# Altium schematic sheet HeatCompressPCB.SchDoc
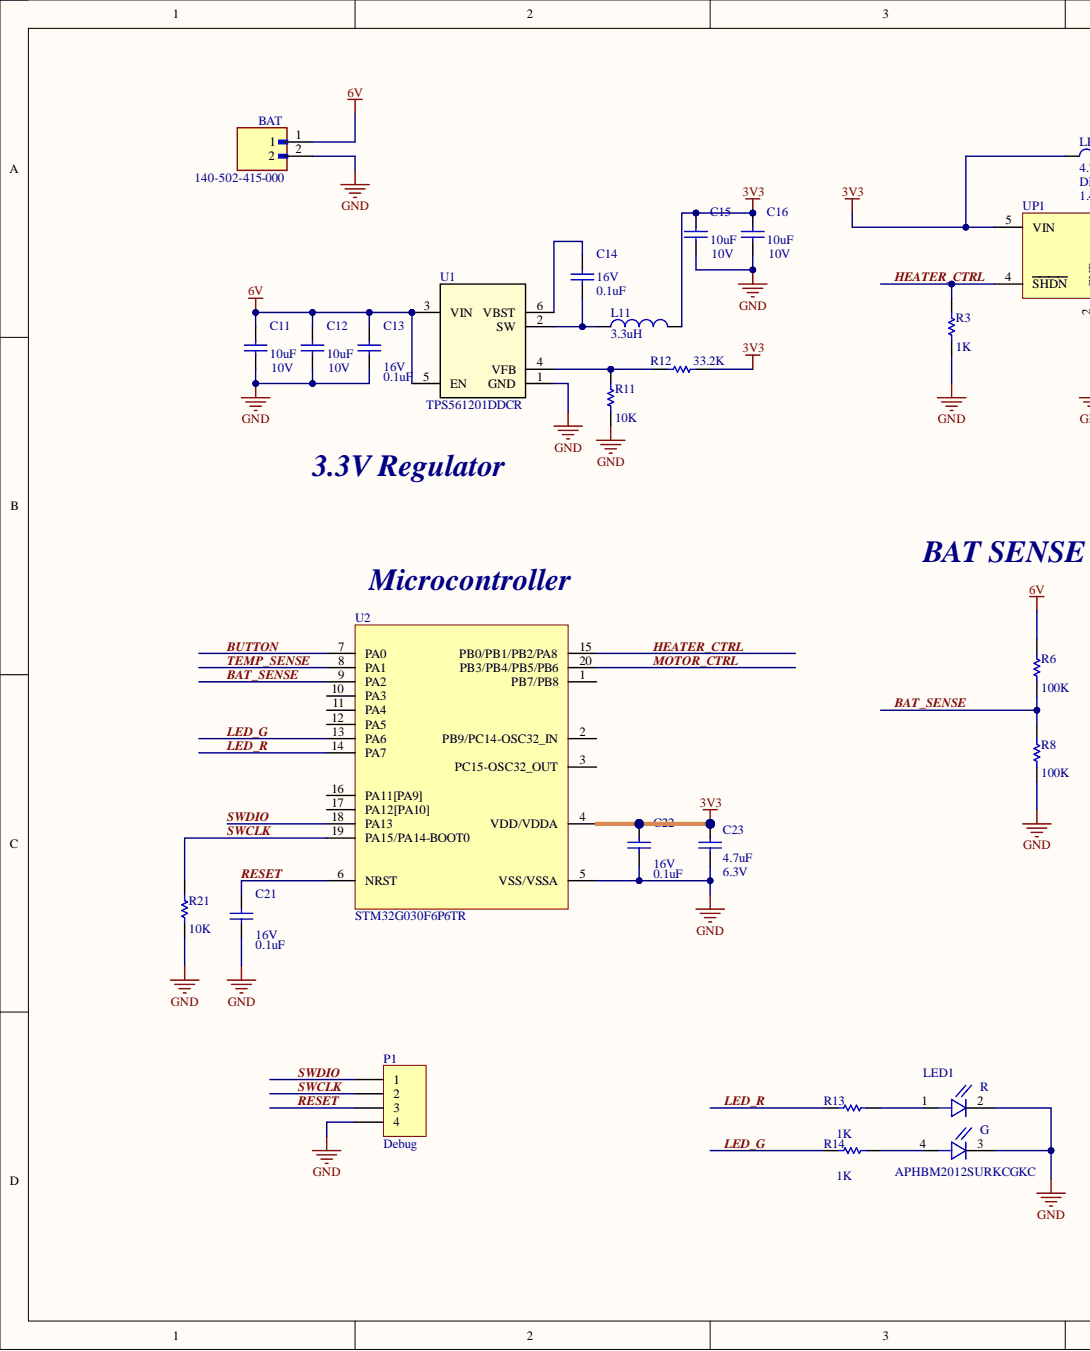
[diagram p0: HeatCompressPCB.SchDoc, left]
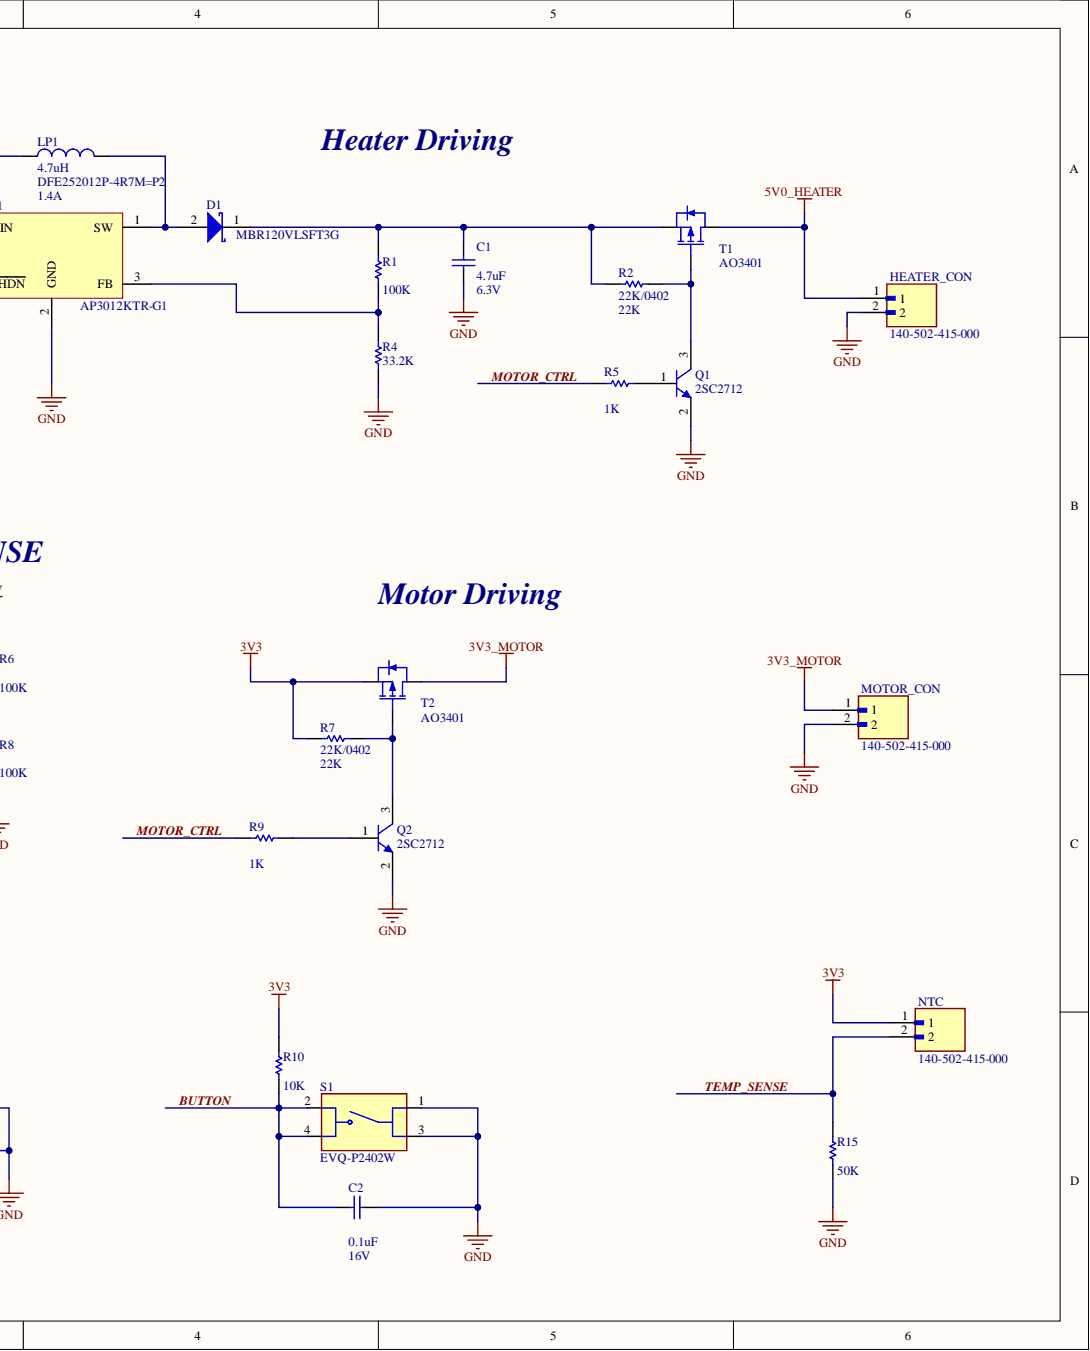
[diagram p1: HeatCompressPCB.SchDoc, right]

## Parts
BAT  140-502-415-000  [140502415000]
C1  value 4.7uF  Capacitance: 4.7uF  Voltage Rating: 6.3V  [C0402]  (hidden comment: 4.7uF/6.3V)
C2  value 0.1uF  Voltage Rating: 16V  [C0402]  (hidden comment: 0.1uF/16V)
C11  value 10uF  Capacitance: 10uF  Voltage Rating: 10V  [C0402]  (hidden comment: 10uF/10V)
C12  value 10uF  Capacitance: 10uF  Voltage Rating: 10V  [C0402]  (hidden comment: 10uF/10V)
C13  0.1uF/16V  value 0.1uF  Voltage Rating: 16V  [C0402]
C14  0.1uF/16V  value 0.1uF  Voltage Rating: 16V  [C0402]
C15  value 10uF  Capacitance: 10uF  Voltage Rating: 10V  [C0402]  (hidden comment: 10uF/10V)
C16  value 10uF  Capacitance: 10uF  Voltage Rating: 10V  [C0402]  (hidden comment: 10uF/10V)
C21  0.1uF/16V  value 0.1uF  Voltage Rating: 16V  [C0402]
C22  0.1uF/16V  value 0.1uF  Voltage Rating: 16V  [C0402]
C23  value 4.7uF  Capacitance: 4.7uF  Voltage Rating: 6.3V  [C0402]  (hidden comment: 4.7uF/6.3V)
D1  MBR120VLSFT3G  [SOD-123FL]
HEATER_CON  140-502-415-000  [140502415000]
L11  3.3uH  [DFE252012P-1R0M=P2]
LED1  APHBM2012SURKCGKC  [LED_APHBM2012SURKCGKC]
LP1  4.7uH  Manufacturer Part Number: DFE252012P-4R7M=P2  [DFE252012P-1R0M=P2]
MOTOR_CON  140-502-415-000  [140502415000]
NTC  140-502-415-000  [140502415000]
P1  Debug  [MHDR1X4]
Q1  2SC2712  [SOT-23]
Q2  2SC2712  [SOT-23]
R1  value 100K  [R0402]  (hidden comment: 100K/0402)
R2  22K/0402  value 22K  [R0402]
R3  value 1K  [R0402]  (hidden comment: 1K/0402)
R4  value 33.2K  [R0402]  (hidden comment: 33.2K/0402)
R5  value 1K  [R0402]  (hidden comment: 1K/0402)
R6  value 100K  [R0402]  (hidden comment: 100K/0402)
R7  22K/0402  value 22K  [R0402]
R8  value 100K  [R0402]  (hidden comment: 100K/0402)
R9  value 1K  [R0402]  (hidden comment: 1K/0402)
R10  value 10K  [R0402]  (hidden comment: 10K/0402)
R11  value 10K  [R0402]  (hidden comment: 10K/0402)
R12  value 33.2K  [R0402]  (hidden comment: 33.2K/0402)
R13  value 1K  [R0402]  (hidden comment: 1K/0402)
R14  value 1K  [R0402]  (hidden comment: 1K/0402)
R15  value 50K  [R0402]  (hidden comment: 50K/0402)
R21  value 10K  [R0402]  (hidden comment: 10K/0402)
S1  EVQ-P2402W  [SW_EVQ-P2402W]
T1  AO3401  [SOT-23]
T2  AO3401  [SOT-23]
U1  TPS561201DDCR  [DDC0006A_N]
U2  STM32G030F6P6TR  [TSOP65P640X120-20N]
UP1  AP3012KTR-G1  [SOT23-5]

## Nets
3V3: C15.2 C16.2 C22.2 C23.1 L11.2 LP1.1 NTC.1 R7.2 R10.2 R12.1 T2.2 U2.4(VDD/VDDA) UP1.5(VIN)
3V3_MOTOR: MOTOR_CON.1 T2.3
5V0_HEATER: HEATER_CON.1 T1.3
6V: BAT.1 C11.2 C12.2 C13.2 R6.1 U1.3(VIN) U1.5(EN)
GND: BAT.2 C1.2 C2.1 C11.1 C12.1 C13.1 C15.1 C16.1 C21.1 C22.1 C23.2 HEATER_CON.2 LED1.2 LED1.3 MOTOR_CON.2 P1.4 Q1.2 Q2.2 R3.2 R4.2 R8.2 R11.1 R15.2 R21.1 S1.1 S1.3 U1.1(GND) U2.5(VSS/VSSA) UP1.2(GND)
BAT_SENSE: R6.2 R8.1 U2.9(PA2)
BUTTON: C2.2 R10.1 S1.2 S1.4 U2.7(PA0)
HEATER_CTRL: R3.1 U2.15(PB0/PB1/PB2/PA8) UP1.4(SHDN)
LED_G: R14.2 U2.13(PA6)
LED_R: R13.2 U2.14(PA7)
MOTOR_CTRL: R5.2 R9.2 U2.20(PB3/PB4/PB5/PB6)
RESET: C21.2 P1.3 U2.6(NRST)
SWCLK: P1.2 R21.2 U2.19(PA15/PA14-BOOT0)
SWDIO: P1.1 U2.18(PA13)
TEMP_SENSE: NTC.2 R15.1 U2.8(PA1)
NetC1_1: C1.1 D1.1 R1.1 R2.2 T1.2
NetC14_1: C14.1 L11.1 U1.2(SW)
NetC14_2: C14.2 U1.6(VBST)
NetD1_2: D1.2 LP1.2 UP1.1(SW)
NetLED1_1: LED1.1 R13.1
NetLED1_4: LED1.4 R14.1
NetQ1_1: Q1.1 R5.1
NetQ1_3: Q1.3 R2.1 T1.1
NetQ2_1: Q2.1 R9.1
NetQ2_3: Q2.3 R7.1 T2.1
NetR1_2: R1.2 R4.1 UP1.3(FB)
NetR11_2: R11.2 R12.2 U1.4(VFB)

Not connected: U2 1(PB7/PB8) 2(PB9/PC14-OSC32_IN) 3(PC15-OSC32_OUT) 10(PA3) 11(PA4) 12(PA5) 16(PA11[PA9]) 17(PA12[PA10])

## Library data of the parts
BAT HEATER_CON MOTOR_CON NTC = 140-502-415-000: EDAC - 140-502-415-000 - PIN HEADER CONN, BRASS, 2POS, 1ROW, 2MM   Source:  Datasheet; GateName_1: G$1; Component Kind: Standard; DeviceSetName: 140-502-415-000; Height: 5.25mm; Library Name: MainSchlib.SchLib; LibraryName: 140-502-415-000; Manufacturer_Name: EDAC; Manufacturer_Part_Number: 140-502-415-000; Mouser_Part_Number: 587-140-502-415-000; Mouser_Price-Stock: https://www.mouser.co.uk/ProductDetail/EDAC/140-502-415-000?qs=zW32dvEIR3v5NSNdqekIBw%3D%3D; Package: 140502415000; SymbolName_1: 140-502-415-000
  BAT: Supplier 1: Digi-Key; Supplier Part Number 1: 151-140-502-415-000-ND
C1 C23 = 885012206052: CAP CER 4.7UF 6.3V X5R 0402; Manufacturer: KEMET; Manufacturer Part Number: C0402C475M9PAC7867; Tolerance: 10%; Case Code (Imperial): 0603; Case Code (Metric): 1608; Case/Package: 0402; Component Kind: Standard; ComponentLink1Description: https://datasheet.ciiva.com/176/mlccorderingcode-176788.pdf; ComponentLink1URL: https://datasheet.ciiva.com/176/mlccorderingcode-176788.pdf; ComponentLink2Description: https://datasheet.ciiva.com/176/mlccorderingcode-176789.pdf; ComponentLink2URL: https://datasheet.ciiva.com/176/mlccorderingcode-176789.pdf; ComponentLink3Description: https://datasheet.ciiva.com/176/mlccorderingcode-176790.pdf; ComponentLink3URL: https://datasheet.ciiva.com/176/mlccorderingcode-176790.pdf; ComponentLink4Description: https://datasheet.ciiva.com/5425/upy-gphc-x7r-6-3v-to-50v-8-12304-5425495.pdf; ComponentLink4URL: https://datasheet.ciiva.com/5425/upy-gphc-x7r-6-3v-to-50v-8-12304-5425495.pdf; ComponentLink5Description: https://datasheet.ciiva.com/16625/upy-gphc-x7r-6-3v-to-50v-8-16625527.pdf; ComponentLink5URL: https://datasheet.ciiva.com/16625/upy-gphc-x7r-6-3v-to-50v-8-16625527.pdf; ComponentLink6Description: https://datasheet.ciiva.com/8033/1734573-8033800.pdf; ComponentLink6URL: https://datasheet.ciiva.com/8033/1734573-8033800.pdf; ComponentLink7Description: https://datasheet.ciiva.com/8033/1734573-8033801.pdf; ComponentLink7URL: https://datasheet.ciiva.com/8033/1734573-8033801.pdf; ComponentLink8Description: https://datasheet.ciiva.com/28450/1489800-28450557.pdf; ComponentLink8URL: https://datasheet.ciiva.com/28450/1489800-28450557.pdf; ComponentLink9Description: https://datasheet.ciiva.com/7978/1489800-7978143.pdf; ComponentLink9URL: https://datasheet.ciiva.com/7978/1489800-7978143.pdf; ComponentLink10Description: https://datasheet.ciiva.com/25788/cl10b105kp8nnnc-25788304.pdf; ComponentLink10URL: https://datasheet.ciiva.com/25788/cl10b105kp8nnnc-25788304.pdf; ComponentLink11Description: https://datasheet.ciiva.com/16396/cl10b105kp8nnnc-16396970.pdf; ComponentLink11URL: https://datasheet.ciiva.com/16396/cl10b105kp8nnnc-16396970.pdf; ComponentLink12Description: https://datasheet.ciiva.com/26838/getdatasheetpartid-358410-26838426.pdf; ComponentLink12URL: https://datasheet.ciiva.com/26838/getdatasheetpartid-358410-26838426.pdf; ComponentLink13Description: http://www.samsungsem.com/kr/front/downloadcms.do?path=/kr/support/product-search/mlcc/__icsFiles/afieldfile/2014/11/05&fileName=C_CL10A475KQ8NNWC.pdf; ComponentLink13URL: http://www.samsungsem.com/kr/front/downloadcms.do?path=/kr/support/product-search/mlcc/__icsFiles/afieldfile/2014/11/05&fileName=C_CL10A475KQ8NNWC.pdf; Length: 0.063inch; Library Name: Capacitor_Ceramic.IntLib; Max Operating Temperature: 85°C; Min Operating Temperature: -55°C; Mount: Surface Mount; Package Quantity: 1; Packaging: Tape and Reel; Pricing 1: 1=0.1, 10=0.075, 100=0.0333, 500=0.02376, 1000=0.01867 (USD); Signal Integrity: Cap; Simulation: Cap; Stock 1: 40000; Supplier 1: Digi-Key; Supplier Part Number 1: 399-13068-1-ND; Thickness: 0.035inch; Width: 0.031inch
C2 C13 C14 C21 C22 = Cap: CAP CER 0.1UF 16V X7R 0402; Manufacturer: Murata Electronics; Manufacturer Part Number: GRM155R71C104KA88J; Category: Ceramic Capacitors; Component Kind: Standard; ComponentLink1Description: https://datasheet.ciiva.com/30062/tmk212bbj106kg-t-30062083.pdf?src-supplier=Onlinecomponents.com; ComponentLink1URL: https://datasheet.ciiva.com/30062/tmk212bbj106kg-t-30062083.pdf?src-supplier=Onlinecomponents.com; ComponentLink2Description: https://datasheet.ciiva.com/139/mlcc-all-e-139570.pdf?src-supplier=Digi-Key; ComponentLink2URL: https://datasheet.ciiva.com/139/mlcc-all-e-139570.pdf?src-supplier=Digi-Key; ComponentLink3Description: https://datasheet.ciiva.com/139/mlcc-all-e-139571.pdf?src-supplier=Digi-Key; ComponentLink3URL: https://datasheet.ciiva.com/139/mlcc-all-e-139571.pdf?src-supplier=Digi-Key; ComponentLink4Description: https://datasheet.ciiva.com/139/mlcc-all-e-139572.pdf?src-supplier=Digi-Key; ComponentLink4URL: https://datasheet.ciiva.com/139/mlcc-all-e-139572.pdf?src-supplier=Digi-Key; ComponentLink5Description: https://datasheet.ciiva.com/7975/1481447-7975820.pdf?src-supplier=Element14; ComponentLink5URL: https://datasheet.ciiva.com/7975/1481447-7975820.pdf?src-supplier=Element14; ComponentLink6Description: https://datasheet.ciiva.com/144/mlccorderingcode-144037.pdf?src-supplier=Digi-Key; ComponentLink6URL: https://datasheet.ciiva.com/144/mlccorderingcode-144037.pdf?src-supplier=Digi-Key; ComponentLink7Description: https://datasheet.ciiva.com/8033/1489800-8033669.pdf?src-supplier=Element14; ComponentLink7URL: https://datasheet.ciiva.com/8033/1489800-8033669.pdf?src-supplier=Element14; ComponentLink8Description: https://datasheet.ciiva.com/15189/getdatasheetpartid-321421-15189125.pdf?src-supplier=Verical; ComponentLink8URL: https://datasheet.ciiva.com/15189/getdatasheetpartid-321421-15189125.pdf?src-supplier=Verical; ComponentLink9Description: https://datasheet.ciiva.com/144/mlccorderingcode-144038.pdf?src-supplier=Digi-Key; ComponentLink9URL: https://datasheet.ciiva.com/144/mlccorderingcode-144038.pdf?src-supplier=Digi-Key; ComponentLink10Description: https://datasheet.ciiva.com/28450/1489800-28450342.pdf?src-supplier=Element14; ComponentLink10URL: https://datasheet.ciiva.com/28450/1489800-28450342.pdf?src-supplier=Element14; ComponentLink11Description: https://datasheet.ciiva.com/144/mlccorderingcode-144039.pdf?src-supplier=Digi-Key; ComponentLink11URL: https://datasheet.ciiva.com/144/mlccorderingcode-144039.pdf?src-supplier=Digi-Key; ComponentLink12Description: https://datasheet.ciiva.com/8033/1489800-8033671.pdf?src-supplier=Element14; ComponentLink12URL: https://datasheet.ciiva.com/8033/1489800-8033671.pdf?src-supplier=Element14; ComponentLink13Description: http://www.farnell.com/datasheets/1872206.pdf?src-supplier=Farnell; ComponentLink13URL: http://www.farnell.com/datasheets/1872206.pdf?src-supplier=Farnell; ComponentLink14Description: http://uk.rs-online.com/webdocs/144c/0900766b8144c113.pdf?src-supplier=RSComponents; ComponentLink14URL: http://uk.rs-online.com/webdocs/144c/0900766b8144c113.pdf?src-supplier=RSComponents; ComponentLink15Description: http://www.farnell.com/datasheets/2048008.pdf?src-supplier=Farnell; ComponentLink15URL: http://www.farnell.com/datasheets/2048008.pdf?src-supplier=Farnell; ComponentLink16Description: http://www.mouser.com/ds/2/281/c02e-2905.pdf?src-supplier=Mouser; ComponentLink16URL: http://www.mouser.com/ds/2/281/c02e-2905.pdf?src-supplier=Mouser; ComponentLink17Description: http://components.arrow.com/part/dataview/0323_06361249?src-supplier=Arrow+Europe; ComponentLink17URL: http://components.arrow.com/part/dataview/0323_06361249?src-supplier=Arrow+Europe; ComponentLink18Description: https://search.murata.co.jp/Ceramy/image/img/A01X/G101/ENG/GRM155R71E104KE14-01.pdf?src-supplier=Digi-Key; ComponentLink18URL: https://search.murata.co.jp/Ceramy/image/img/A01X/G101/ENG/GRM155R71E104KE14-01.pdf?src-supplier=Digi-Key; ComponentLink19Description: http://www.farnell.com/datasheets/2048008.pdf?src-supplier=Newark; ComponentLink19URL: http://www.farnell.com/datasheets/2048008.pdf?src-supplier=Newark; ComponentLink20Description: http://psearch.en.murata.com/capacitor/product/GRM155R71E104KE14%23.pdf?src-supplier=Avnet; ComponentLink20URL: http://psearch.en.murata.com/capacitor/product/GRM155R71E104KE14%23.pdf?src-supplier=Avnet; ComponentLink21Description: http://www.farnell.com/datasheets/2048008.pdf?src-supplier=Element14; ComponentLink21URL: http://www.farnell.com/datasheets/2048008.pdf?src-supplier=Element14; Library Name: Miscellaneous Devices.IntLib; Pricing 1: 1=0.10000, 10=0.06400, 50=0.03500, 100=0.02860, 500=0.02040, 1000=0.01603, 2500=0.01458, 5000=0.01341 (USD); RoHS: Yes; RoHS Compliant: Yes; Signal Integrity: Cap; Simulation: CAP; Stock 1: 586694; Supplier 1: Digi-Key; Supplier Part Number 1: 490-6328-1-ND
C11 C12 C15 C16 = Cap: CAP CER 10UF 10V X5R 0402; Manufacturer: Samsung Electro-Mechanics; Manufacturer Part Number: CL05A106MP5NUNC; Tolerance: 10%; Case Code (Imperial): 0402; Case/Package: 0402; Category: Ceramic Capacitors; Component Kind: Standard; ComponentLink1Description: https://datasheet.ciiva.com/30062/tmk212bbj106kg-t-30062083.pdf?src-supplier=Onlinecomponents.com; ComponentLink1URL: https://datasheet.ciiva.com/30062/tmk212bbj106kg-t-30062083.pdf?src-supplier=Onlinecomponents.com; ComponentLink2Description: https://datasheet.ciiva.com/139/mlcc-all-e-139570.pdf?src-supplier=Digi-Key; ComponentLink2URL: https://datasheet.ciiva.com/139/mlcc-all-e-139570.pdf?src-supplier=Digi-Key; ComponentLink3Description: https://datasheet.ciiva.com/139/mlcc-all-e-139571.pdf?src-supplier=Digi-Key; ComponentLink3URL: https://datasheet.ciiva.com/139/mlcc-all-e-139571.pdf?src-supplier=Digi-Key; ComponentLink4Description: https://datasheet.ciiva.com/139/mlcc-all-e-139572.pdf?src-supplier=Digi-Key; ComponentLink4URL: https://datasheet.ciiva.com/139/mlcc-all-e-139572.pdf?src-supplier=Digi-Key; ComponentLink5Description: https://datasheet.ciiva.com/7975/1481447-7975820.pdf?src-supplier=Element14; ComponentLink5URL: https://datasheet.ciiva.com/7975/1481447-7975820.pdf?src-supplier=Element14; ComponentLink6Description: https://datasheet.ciiva.com/144/mlccorderingcode-144037.pdf?src-supplier=Digi-Key; ComponentLink6URL: https://datasheet.ciiva.com/144/mlccorderingcode-144037.pdf?src-supplier=Digi-Key; ComponentLink7Description: https://datasheet.ciiva.com/8033/1489800-8033669.pdf?src-supplier=Element14; ComponentLink7URL: https://datasheet.ciiva.com/8033/1489800-8033669.pdf?src-supplier=Element14; ComponentLink8Description: https://datasheet.ciiva.com/15189/getdatasheetpartid-321421-15189125.pdf?src-supplier=Verical; ComponentLink8URL: https://datasheet.ciiva.com/15189/getdatasheetpartid-321421-15189125.pdf?src-supplier=Verical; ComponentLink9Description: https://datasheet.ciiva.com/144/mlccorderingcode-144038.pdf?src-supplier=Digi-Key; ComponentLink9URL: https://datasheet.ciiva.com/144/mlccorderingcode-144038.pdf?src-supplier=Digi-Key; ComponentLink10Description: https://datasheet.ciiva.com/28450/1489800-28450342.pdf?src-supplier=Element14; ComponentLink10URL: https://datasheet.ciiva.com/28450/1489800-28450342.pdf?src-supplier=Element14; ComponentLink11Description: https://datasheet.ciiva.com/144/mlccorderingcode-144039.pdf?src-supplier=Digi-Key; ComponentLink11URL: https://datasheet.ciiva.com/144/mlccorderingcode-144039.pdf?src-supplier=Digi-Key; ComponentLink12Description: https://datasheet.ciiva.com/8033/1489800-8033671.pdf?src-supplier=Element14; ComponentLink12URL: https://datasheet.ciiva.com/8033/1489800-8033671.pdf?src-supplier=Element14; ComponentLink13Description: http://www.farnell.com/datasheets/1872206.pdf?src-supplier=Farnell; ComponentLink13URL: http://www.farnell.com/datasheets/1872206.pdf?src-supplier=Farnell; ComponentLink14Description: http://uk.rs-online.com/webdocs/144c/0900766b8144c113.pdf?src-supplier=RSComponents; ComponentLink14URL: http://uk.rs-online.com/webdocs/144c/0900766b8144c113.pdf?src-supplier=RSComponents; ComponentLink15Description: http://www.farnell.com/datasheets/2048008.pdf?src-supplier=Farnell; ComponentLink15URL: http://www.farnell.com/datasheets/2048008.pdf?src-supplier=Farnell; ComponentLink16Description: http://www.mouser.com/ds/2/281/c02e-2905.pdf?src-supplier=Mouser; ComponentLink16URL: http://www.mouser.com/ds/2/281/c02e-2905.pdf?src-supplier=Mouser; ComponentLink17Description: http://components.arrow.com/part/dataview/0323_06361249?src-supplier=Arrow+Europe; ComponentLink17URL: http://components.arrow.com/part/dataview/0323_06361249?src-supplier=Arrow+Europe; ComponentLink18Description: https://search.murata.co.jp/Ceramy/image/img/A01X/G101/ENG/GRM155R71E104KE14-01.pdf?src-supplier=Digi-Key; ComponentLink18URL: https://search.murata.co.jp/Ceramy/image/img/A01X/G101/ENG/GRM155R71E104KE14-01.pdf?src-supplier=Digi-Key; ComponentLink19Description: http://www.farnell.com/datasheets/2048008.pdf?src-supplier=Newark; ComponentLink19URL: http://www.farnell.com/datasheets/2048008.pdf?src-supplier=Newark; ComponentLink20Description: http://psearch.en.murata.com/capacitor/product/GRM155R71E104KE14%23.pdf?src-supplier=Avnet; ComponentLink20URL: http://psearch.en.murata.com/capacitor/product/GRM155R71E104KE14%23.pdf?src-supplier=Avnet; ComponentLink21Description: http://www.farnell.com/datasheets/2048008.pdf?src-supplier=Element14; ComponentLink21URL: http://www.farnell.com/datasheets/2048008.pdf?src-supplier=Element14; ComponentLink22Description: https://datasheet.ciiva.com/25796/cl21a106kaynnne-25796720.pdf?src-supplier=Digi-Key; ComponentLink22URL: https://datasheet.ciiva.com/25796/cl21a106kaynnne-25796720.pdf?src-supplier=Digi-Key; ComponentLink23Description: https://datasheet.ciiva.com/26838/getdatasheetpartid-357558-26838583.pdf?src-supplier=Verical; ComponentLink23URL: https://datasheet.ciiva.com/26838/getdatasheetpartid-357558-26838583.pdf?src-supplier=Verical; Construction: Flat; Depth: 1.25mm; Dielectric: X5R; Height: 1.25mm; Length: 2mm; Library Name: Miscellaneous Devices.IntLib; Max Operating Temperature: 85°C; Min Operating Temperature: -55°C; Mount: Surface Mount; Package Quantity: 1; Packaging: Tape and Reel; Pricing 1: 1=0.20000, 10=0.14000, 50=0.07920, 100=0.06570, 500=0.04606, 1000=0.03814 (USD); Radiation Hardening: No; RoHS: Yes; RoHS Compliant: Yes; Series: CL; Signal Integrity: Cap; Simulation: CAP; Stock 1: 1908498; Supplier 1: Digi-Key; Supplier Part Number 1: 1276-1450-1-ND; Thickness: 0.057inch; Width: 0.049inch
  C11 C12: Case Code (Metric): 1015
  C15 C16: Case Code (Metric): 2012
D1 = D Schottky: DIODE SCHOTTKY 20V 1A SOD123FL; Manufacturer: ON Semiconductor; Element Configuration: Single; Forward Voltage: 340mV; Max Forward Surge Current (Ifsm): 45A; Max Reverse Leakage Current: 600uA; Polarity: Standard; Value: 20V 1A; Average Rectified Current: 1A; Component Kind: Standard; ComponentLink1Description: http://www.onsemi.com/pub_link/Collateral/MBR0520LT1-D.PDF; ComponentLink1URL: http://www.onsemi.com/pub_link/Collateral/MBR0520LT1-D.PDF; ComponentLink2Description: https://datasheet.ciiva.com/4715/mbr120vlsft1-d-107081-4715104.pdf; ComponentLink2URL: https://datasheet.ciiva.com/4715/mbr120vlsft1-d-107081-4715104.pdf; ComponentLink3Description: https://datasheet.ciiva.com/6380/mbr120vlsft1-d-6380907.pdf; ComponentLink3URL: https://datasheet.ciiva.com/6380/mbr120vlsft1-d-6380907.pdf; ComponentLink4Description: https://datasheet.ciiva.com/8294/mbr120vlsft1-d-8294603.pdf; ComponentLink4URL: https://datasheet.ciiva.com/8294/mbr120vlsft1-d-8294603.pdf; ComponentLink5Description: https://datasheet.ciiva.com/6380/mbr120vlsft1-d-6380910.pdf; ComponentLink5URL: https://datasheet.ciiva.com/6380/mbr120vlsft1-d-6380910.pdf; Current Rating: 1A; Forward Current: 1A; Halogen Free: Halogen Free; Lead Free: Lead Free; Library Name: MainSchlib.SchLib; Manufacturer Part Number: MBR120VLSFT3G; Max Operating Temperature: 125°C; Max Repetitive Reverse Voltage (Vrrm): 20V; Max Reverse Voltage (DC): 20V; Max Surge Current: 45A; Min Operating Temperature: -65°C; Number of Pins: 2; Output Current: 1A; Package Quantity: 10000; Packaging: Tape and Reel; Peak Non-Repetitive Surge Current: 45A; Peak Reverse Current: 600uA; Pricing 1: 1=0.42, 10=0.338, 100=0.2298, 500=0.17234, 1000=0.12926 (USD); Radiation Hardening: No; REACH SVHC: No SVHC; Signal Integrity: D Schottky; Simulation: SKYDIODE; Stock 1: 46165; Supplier 1: Digi-Key; Supplier Part Number 1: MBR120VLSFT3GOSCT-ND; Voltage Rating (DC): 20V
L11 = Inductor: Inductor Power Shielded Wirewound 3.3uH 20% 1MHz Metal 1.7A 0.14Ohm DCR 1008 T/R; Manufacturer: Murata; Manufacturer Part Number: DFE252012P-3R3M=P2; Core Material: Ferrite; Current Rating: 2.3A; DC Resistance (DCR): 140mR; Case Code (Imperial): 1008; Case Code (Metric): 2520; Case/Package: 1008; Category: Fixed Inductors; Component Kind: Standard; ComponentLink1Description: https://datasheet.ciiva.com/22738/1681947-22738123.pdf?src-supplier=Element14; ComponentLink1URL: https://datasheet.ciiva.com/22738/1681947-22738123.pdf?src-supplier=Element14; ComponentLink2Description: https://datasheet.ciiva.com/22738/1681947-22738125.pdf?src-supplier=Element14; ComponentLink2URL: https://datasheet.ciiva.com/22738/1681947-22738125.pdf?src-supplier=Element14; ComponentLink3Description: https://datasheet.ciiva.com/22738/1681947-22738126.pdf?src-supplier=Element14; ComponentLink3URL: https://datasheet.ciiva.com/22738/1681947-22738126.pdf?src-supplier=Element14; ComponentLink4Description: https://datasheet.ciiva.com/22738/1681947-22738108.pdf?src-supplier=Element14; ComponentLink4URL: https://datasheet.ciiva.com/22738/1681947-22738108.pdf?src-supplier=Element14; ComponentLink5Description: https://datasheet.ciiva.com/22738/1681947-22738109.pdf?src-supplier=Element14; ComponentLink5URL: https://datasheet.ciiva.com/22738/1681947-22738109.pdf?src-supplier=Element14; ComponentLink6Description: https://datasheet.ciiva.com/22738/1681947-22738111.pdf?src-supplier=Element14; ComponentLink6URL: https://datasheet.ciiva.com/22738/1681947-22738111.pdf?src-supplier=Element14; ComponentLink7Description: http://www.farnell.com/datasheets/2550137.pdf?src-supplier=Farnell; ComponentLink7URL: http://www.farnell.com/datasheets/2550137.pdf?src-supplier=Farnell; ComponentLink8Description: https://datasheet.octopart.com/DFE252012P-3R3M%3DP2-Murata-datasheet-99731374.pdf?src-supplier=Farnell; ComponentLink8URL: https://datasheet.octopart.com/DFE252012P-3R3M%3DP2-Murata-datasheet-99731374.pdf?src-supplier=Farnell; ComponentLink9Description: http://www.toko.co.jp/products/pdf/inductors/dfe252012p.pdf?src-supplier=Avnet; ComponentLink9URL: http://www.toko.co.jp/products/pdf/inductors/dfe252012p.pdf?src-supplier=Avnet; ComponentLink10Description: http://www.murata.com/~/media/webrenewal/products/inductor/chip/tokoproducts/wirewoundmetalalloychiptype/m_dfe252012p.ashx?src-supplier=Digi-Key; ComponentLink10URL: http://www.murata.com/~/media/webrenewal/products/inductor/chip/tokoproducts/wirewoundmetalalloychiptype/m_dfe252012p.ashx?src-supplier=Digi-Key; ComponentLink11Description: https://www.murata.com/~/media/webrenewal/products/inductor/chip/tokoproducts/wirewoundmetalalloychiptype/m_dfe252012p.ashx?src-supplier=Digi-Key; ComponentLink11URL: https://www.murata.com/~/media/webrenewal/products/inductor/chip/tokoproducts/wirewoundmetalalloychiptype/m_dfe252012p.ashx?src-supplier=Digi-Key; ComponentLink12Description: https://datasheet.octopart.com/DFE252012P-3R3M%3DP2-Murata-datasheet-62290040.pdf?src-supplier=Element14; ComponentLink12URL: https://datasheet.octopart.com/DFE252012P-3R3M%3DP2-Murata-datasheet-62290040.pdf?src-supplier=Element14; ComponentLink13Description: https://datasheet.octopart.com/DFE252012P-3R3M%3DP2-Murata-datasheet-44382719.pdf?src-supplier=Future+Electronics; ComponentLink13URL: https://datasheet.octopart.com/DFE252012P-3R3M%3DP2-Murata-datasheet-44382719.pdf?src-supplier=Future+Electronics; ComponentLink14Description: https://datasheet.octopart.com/DFE252012P-3R3M%3DP2-Murata-datasheet-142219488.pdf?src-supplier=Arrow.cn; ComponentLink14URL: https://datasheet.octopart.com/DFE252012P-3R3M%3DP2-Murata-datasheet-142219488.pdf?src-supplier=Arrow.cn; Depth: 2mm; Height - Seated (Max): 0.039inch; Inductance: 3.3uH; Length: 0.098inch; Library Name: Miscellaneous Devices.IntLib; Max DC Current: 1.7A; Max Operating Temperature: 125°C; Min Operating Temperature: -40°C; Mount: Surface Mount; Packaging: Cut Tape; Pricing 1: 1=0.27, 10=0.24, 25=0.206, 50=0.1888, 100=0.1717, 250=0.1614, 500=0.14422, 1000=0.11676 (USD); RoHS: Yes; RoHS Compliant: Yes; Series: DFE252012P; Shielding: Shielded; Signal Integrity: Inductor; Simulation: INDUCTOR; Stock 1: 11487; Supplier 1: Digi-Key; Supplier Part Number 1: 490-10650-1-ND; Test Frequency: 1MHz; Tolerance: 20%; Value: 3.3uH; Width: 0.079inch
LED1 = APHBM2012SURKCGKC: Green, Red 570nm Green, 630nm Red LED Indication - Discrete 2.1V Green, 1.95V Red 0805 _2012 Metric_; MF: Kingbright; Component Kind: Standard; Library Name: MainSchlib.SchLib; MP: APHBM2012SURKCGKC; Package: 0805 Kingbright; SnapEDA_Link: https://www.snapeda.com/parts/APHBM2012SURKCGKC/Kingbright/view-part/522213/?ref=snap
LP1 = Inductor: FIXED IND 4.7UH 1.4A 0.2OHM SMD; Manufacturer: Murata Electronics; Core Material: Ferrite; DC Resistance (DCR): 216mR; Inductance Tolerance: 20%; Max DC Current: 840mA; Saturation Current: 1.2A; Self Resonant Frequency: 24MHz; Shielding: Shielded; Test Frequency: 100kHz; Case/Package: 1515; Category: Fixed Inductors; Component Kind: Standard; ComponentLink1Description: https://datasheet.ciiva.com/7197/nr4018t100m-ss-7197554.pdf?src-supplier=Newark; ComponentLink1URL: https://datasheet.ciiva.com/7197/nr4018t100m-ss-7197554.pdf?src-supplier=Newark; ComponentLink2Description: https://datasheet.ciiva.com/15988/842nr4018t100m-15988041.pdf?src-supplier=Onlinecomponents.com; ComponentLink2URL: https://datasheet.ciiva.com/15988/842nr4018t100m-15988041.pdf?src-supplier=Onlinecomponents.com; ComponentLink3Description: https://datasheet.ciiva.com/1043/wound04-e-1043520.pdf?src-supplier=Digi-Key; ComponentLink3URL: https://datasheet.ciiva.com/1043/wound04-e-1043520.pdf?src-supplier=Digi-Key; ComponentLink4Description: https://datasheet.ciiva.com/4957/wound04-e-4957580.pdf?src-supplier=Mouser; ComponentLink4URL: https://datasheet.ciiva.com/4957/wound04-e-4957580.pdf?src-supplier=Mouser; ComponentLink5Description: https://datasheet.ciiva.com/1043/wound04-e-1043518.pdf?src-supplier=Digi-Key; ComponentLink5URL: https://datasheet.ciiva.com/1043/wound04-e-1043518.pdf?src-supplier=Digi-Key; ComponentLink6Description: https://datasheet.ciiva.com/1043/wound04-e-1043519.pdf?src-supplier=Digi-Key; ComponentLink6URL: https://datasheet.ciiva.com/1043/wound04-e-1043519.pdf?src-supplier=Digi-Key; Composition: Wirewound; Current Rating: 1.4A; Halogen Free: Halogen Free; Height: 1.8mm; Height - Seated (Max): 0.071inch; Inductance: 4.7uH; Lead Free: Lead Free; Length: 0.157inch; Library Name: MainSchlib.SchLib; Max Operating Temperature: 120°C; Min Operating Temperature: -25°C; Mount: Surface Mount; Number of Pins: 2; Package Quantity: 3500; Packaging: Tape and Reel; Pricing 1: 1=0.41000, 10=0.36500, 25=0.31920, 50=0.27340, 100=0.25070, 250=0.22792, 500=0.21422, 1000=0.16409 (USD); REACH SVHC: Unknown; RMS Current (Irms): 840mA; RoHS: Yes; Stock 1: 37; Supplier 1: Digi-Key; Supplier Part Number 1: 490-10651-1-ND; Termination: SMD/SMT; Tolerance: 20%; Value: 4.7uH; Width: 0.157inch
P1 = MHDR1X4: Header, 4-Pin; Component Kind: Standard; LatestRevisionDate: 17-Jul-2002; LatestRevisionNote: Re-released for DXP Platform.; Library Name: Miscellaneous Connectors.IntLib; Signal Integrity: Connector; Publisher: Altium Limited
Q1 Q2 = NPN-T: TRANS NPN 50V 0.15A SMT3; Manufacturer: Rohm Semiconductor; Manufacturer Part Number: 2SC2412KT146R; Component Kind: Standard; ComponentLink1Description: Digi-Key Link; Library Name: MainSchlib.SchLib; RoHS: Yes; Supplier 1: Digi-Key; Supplier Part Number 1: 2SC2412KT146RCT-ND
R1 R6 R8 = Res1: RES 100K OHM 1% 1/16W 0402; Manufacturer: Yageo; Manufacturer Part Number: RC0402FR-07100KL; Max Power Dissipation: 100mW; Resistance: 10kR; Temperature Coefficient: 150ppm/°C; Tolerance: 5%; Case Code (Imperial): 0603; Case Code (Metric): 1608; Case/Package: 0603; Category: Chip SMD Resistors; Component Kind: Standard; Features: Moisture Resistant; Height: 0.022inch; Length: 0.063inch; Library Name: Miscellaneous Devices.IntLib; Max Operating Temperature: 155°C; Min Operating Temperature: -55°C; Number of Terminations: 2; Packaging: Tape and Reel; Pricing 1: 1=0.10000, 10=0.04200, 50=0.04200, 100=0.01700, 1000=0.00800, 2500=0.00700, 5000=0.00500, 10000=0.00500 (USD); Resistor Type: Thick Film; RoHS: Yes; RoHS Compliant: Yes; Signal Integrity: Res; Simulation: RESISTOR; Stock 1: 45933; Supplier 1: Digi-Key; Supplier Part Number 1: 311-100KLRCT-ND; Width: 0.031inch
R2 R7 = Res1: RES SMD 22K OHM 0.5% 1/16W 0402; Manufacturer: Yageo; Manufacturer Part Number: RT0402DRE0722KL; Max Power Dissipation: 100mW; Resistance: 10kR; Temperature Coefficient: 150ppm/°C; Tolerance: 5%; Case Code (Imperial): 0603; Case Code (Metric): 1608; Case/Package: 0603; Category: Chip SMD Resistors; Component Kind: Standard; Features: Moisture Resistant; Height: 0.022inch; Length: 0.063inch; Library Name: Miscellaneous Devices.IntLib; Max Operating Temperature: 155°C; Min Operating Temperature: -55°C; Number of Terminations: 2; Packaging: Tape and Reel; Pricing 1: 1=0.10000, 10=0.04200, 50=0.04200, 100=0.01700, 1000=0.00800, 2500=0.00700, 5000=0.00500, 10000=0.00500 (USD); Resistor Type: Thick Film; RoHS: Yes; RoHS Compliant: Yes; Signal Integrity: Res; Simulation: RESISTOR; Stock 1: 45933; Supplier 1: Digi-Key; Width: 0.031inch
  R2: Supplier Part Number 1: 311-2256-1-ND
R3 R5 R9 R13 R14 = Res1: RES 1K OHM 1% 1/16W 0402; Manufacturer: Yageo; Manufacturer Part Number: RC0402FR-071KL; Max Power Dissipation: 100mW; Resistance: 10kR; Temperature Coefficient: 150ppm/°C; Tolerance: 5%; Case Code (Imperial): 0603; Case Code (Metric): 1608; Case/Package: 0603; Category: Chip SMD Resistors; Component Kind: Standard; Features: Moisture Resistant; Height: 0.022inch; Length: 0.063inch; Library Name: Miscellaneous Devices.IntLib; Max Operating Temperature: 155°C; Min Operating Temperature: -55°C; Number of Terminations: 2; Packaging: Tape and Reel; Pricing 1: 1=0.10000, 10=0.04200, 50=0.04200, 100=0.01700, 1000=0.00800, 2500=0.00700, 5000=0.00500, 10000=0.00500 (USD); Resistor Type: Thick Film; RoHS: Yes; RoHS Compliant: Yes; Signal Integrity: Res; Simulation: RESISTOR; Stock 1: 45933; Supplier 1: Digi-Key; Supplier Part Number 1: 311-1.00KLRCT-ND; Width: 0.031inch
  R13: Value: 1K
R4 R12 = Res Semi: RES 33.2K OHM 1% 1/16W 0402; Manufacturer: Yageo; Manufacturer Part Number: RC0402FR-0733K2L; Category: Chip SMD Resistors; Component Kind: Standard; ComponentLink1Description: http://www.yageo.com/documents/recent/PYu-RC_Group_51_RoHS_L_04.pdf; ComponentLink1URL: http://www.yageo.com/documents/recent/PYu-RC_Group_51_RoHS_L_04.pdf; ComponentLink2Description: https://datasheet.ciiva.com/11821/0900766b80f80784-11821171.pdf; ComponentLink2URL: https://datasheet.ciiva.com/11821/0900766b80f80784-11821171.pdf; ComponentLink3Description: https://datasheet.ciiva.com/16199/aoa0000ce2-16199478.pdf; ComponentLink3URL: https://datasheet.ciiva.com/16199/aoa0000ce2-16199478.pdf; ComponentLink4Description: https://datasheet.ciiva.com/17212/aoa0000ce2-17212102.pdf; ComponentLink4URL: https://datasheet.ciiva.com/17212/aoa0000ce2-17212102.pdf; ComponentLink5Description: https://datasheet.ciiva.com/24023/aoa0000ce2-24023017.pdf; ComponentLink5URL: https://datasheet.ciiva.com/24023/aoa0000ce2-24023017.pdf; ComponentLink6Description: https://datasheet.ciiva.com/28514/1678782-28514185.pdf; ComponentLink6URL: https://datasheet.ciiva.com/28514/1678782-28514185.pdf; ComponentLink7Description: https://datasheet.ciiva.com/43467/1678782-43467402.pdf; ComponentLink7URL: https://datasheet.ciiva.com/43467/1678782-43467402.pdf; ComponentLink8Description: https://datasheet.ciiva.com/27055/1987020-27055643.pdf; ComponentLink8URL: https://datasheet.ciiva.com/27055/1987020-27055643.pdf; ComponentLink9Description: https://datasheet.ciiva.com/48531/aoa0000ce2-48531069.pdf; ComponentLink9URL: https://datasheet.ciiva.com/48531/aoa0000ce2-48531069.pdf; ComponentLink10Description: https://datasheet.ciiva.com/9194/aoa0000ce2-9194142.pdf; ComponentLink10URL: https://datasheet.ciiva.com/9194/aoa0000ce2-9194142.pdf; ComponentLink11Description: https://datasheet.ciiva.com/16782/70260748-16782590.pdf; ComponentLink11URL: https://datasheet.ciiva.com/16782/70260748-16782590.pdf; ComponentLink12Description: https://datasheet.ciiva.com/16786/70260748-16786734.pdf; ComponentLink12URL: https://datasheet.ciiva.com/16786/70260748-16786734.pdf; ComponentLink13Description: https://datasheet.ciiva.com/30201/aoa0000ce2-30201022.pdf; ComponentLink13URL: https://datasheet.ciiva.com/30201/aoa0000ce2-30201022.pdf; ComponentLink14Description: https://datasheet.ciiva.com/7660/38590-7660789.pdf?src-supplier=Element14; ComponentLink14URL: https://datasheet.ciiva.com/7660/38590-7660789.pdf?src-supplier=Element14; ComponentLink15Description: https://datasheet.ciiva.com/15189/getdatasheetpartid-409289-15189591.pdf?src-supplier=Verical; ComponentLink15URL: https://datasheet.ciiva.com/15189/getdatasheetpartid-409289-15189591.pdf?src-supplier=Verical; ComponentLink16Description: https://datasheet.ciiva.com/46440/1868787-46440891.pdf?src-supplier=Element14; ComponentLink16URL: https://datasheet.ciiva.com/46440/1868787-46440891.pdf?src-supplier=Element14; ComponentLink17Description: https://datasheet.ciiva.com/7983/443971-7983596.pdf?src-supplier=Element14; ComponentLink17URL: https://datasheet.ciiva.com/7983/443971-7983596.pdf?src-supplier=Element14; ComponentLink18Description: https://datasheet.ciiva.com/7746/1738695-7746239.pdf?src-supplier=Element14; ComponentLink18URL: https://datasheet.ciiva.com/7746/1738695-7746239.pdf?src-supplier=Element14; ComponentLink19Description: https://datasheet.ciiva.com/22747/443971-22747519.pdf?src-supplier=Element14; ComponentLink19URL: https://datasheet.ciiva.com/22747/443971-22747519.pdf?src-supplier=Element14; ComponentLink20Description: https://datasheet.ciiva.com/11808/0900766b80f96399-11808172.pdf?src-supplier=Rs; ComponentLink20URL: https://datasheet.ciiva.com/11808/0900766b80f96399-11808172.pdf?src-supplier=Rs; ComponentLink21Description: https://datasheet.ciiva.com/5684/443971-5684699.pdf?src-supplier=Farnell; ComponentLink21URL: https://datasheet.ciiva.com/5684/443971-5684699.pdf?src-supplier=Farnell; ComponentLink22Description: https://datasheet.ciiva.com/7983/443971-7983627.pdf?src-supplier=Element14; ComponentLink22URL: https://datasheet.ciiva.com/7983/443971-7983627.pdf?src-supplier=Element14; ComponentLink23Description: https://datasheet.ciiva.com/22643/1868787-22643388.pdf?src-supplier=Element14; ComponentLink23URL: https://datasheet.ciiva.com/22643/1868787-22643388.pdf?src-supplier=Element14; ComponentLink24Description: https://datasheet.ciiva.com/46382/443971-46382072.pdf?src-supplier=Element14; ComponentLink24URL: https://datasheet.ciiva.com/46382/443971-46382072.pdf?src-supplier=Element14; ComponentLink25Description: https://datasheet.ciiva.com/29721/pyu-r-int-thick-7-29721178.pdf?src-supplier=Digi-Key; ComponentLink25URL: https://datasheet.ciiva.com/29721/pyu-r-int-thick-7-29721178.pdf?src-supplier=Digi-Key; ComponentLink26Description: https://datasheet.ciiva.com/29721/pyu-rc0603-51-rohs-l-4-29721179.pdf?src-supplier=Digi-Key; ComponentLink26URL: https://datasheet.ciiva.com/29721/pyu-rc0603-51-rohs-l-4-29721179.pdf?src-supplier=Digi-Key; ComponentLink27Description: https://datasheet.ciiva.com/5558/rc0603-272842-5558341.pdf?src-supplier=Mouser; ComponentLink27URL: https://datasheet.ciiva.com/5558/rc0603-272842-5558341.pdf?src-supplier=Mouser; ComponentLink28Description: https://datasheet.ciiva.com/11808/0900766b80f96399-11808316.pdf?src-supplier=Rs; ComponentLink28URL: https://datasheet.ciiva.com/11808/0900766b80f96399-11808316.pdf?src-supplier=Rs; Library Name: Miscellaneous Devices.IntLib; Pricing 1: 1=0.1, 10=0.018, 50=0.0106, 100=0.0088, 500=0.00592, 1000=0.00505 (USD); RoHS: Yes; Signal Integrity: Res Semi; Simulation: RES; Stock 1: 1402570; Supplier 1: Digi-Key; Supplier Part Number 1: 311-33.2KLRCT-ND
R10 R11 R21 = Res1: RES 10K OHM 5% 1/16W 0402; Manufacturer: Yageo; Manufacturer Part Number: RC0402JR-0710KL; Max Power Dissipation: 100mW; Resistance: 10kR; Temperature Coefficient: 150ppm/°C; Case Code (Imperial): 0603; Case Code (Metric): 1608; Case/Package: 0402; Category: Chip SMD Resistors; Component Kind: Standard; ComponentLink1Description: https://datasheet.ciiva.com/11808/0900766b80c66297-11808764.pdf?src-supplier=RSComponents; ComponentLink1URL: https://datasheet.ciiva.com/11808/0900766b80c66297-11808764.pdf?src-supplier=RSComponents; ComponentLink2Description: https://datasheet.ciiva.com/46394/1018594-46394954.pdf?src-supplier=Element14; ComponentLink2URL: https://datasheet.ciiva.com/46394/1018594-46394954.pdf?src-supplier=Element14; ComponentLink3Description: https://datasheet.ciiva.com/7849/1018594-7849818.pdf?src-supplier=Element14; ComponentLink3URL: https://datasheet.ciiva.com/7849/1018594-7849818.pdf?src-supplier=Element14; ComponentLink4Description: https://datasheet.ciiva.com/7849/1018594-7849819.pdf?src-supplier=Element14; ComponentLink4URL: https://datasheet.ciiva.com/7849/1018594-7849819.pdf?src-supplier=Element14; ComponentLink5Description: https://datasheet.ciiva.com/15189/getdatasheetpartid-323697-15189339.pdf?src-supplier=Verical; ComponentLink5URL: https://datasheet.ciiva.com/15189/getdatasheetpartid-323697-15189339.pdf?src-supplier=Verical; ComponentLink6Description: https://datasheet.ciiva.com/7660/38568-7660787.pdf?src-supplier=Element14; ComponentLink6URL: https://datasheet.ciiva.com/7660/38568-7660787.pdf?src-supplier=Element14; ComponentLink7Description: https://datasheet.ciiva.com/7849/1784430-7849813.pdf?src-supplier=Element14; ComponentLink7URL: https://datasheet.ciiva.com/7849/1784430-7849813.pdf?src-supplier=Element14; ComponentLink8Description: https://datasheet.ciiva.com/7849/1686128-7849814.pdf?src-supplier=Element14; ComponentLink8URL: https://datasheet.ciiva.com/7849/1686128-7849814.pdf?src-supplier=Element14; ComponentLink9Description: https://datasheet.ciiva.com/7849/1784430-7849815.pdf?src-supplier=Element14; ComponentLink9URL: https://datasheet.ciiva.com/7849/1784430-7849815.pdf?src-supplier=Element14; ComponentLink10Description: https://datasheet.ciiva.com/22747/1018594-22747512.pdf?src-supplier=Element14; ComponentLink10URL: https://datasheet.ciiva.com/22747/1018594-22747512.pdf?src-supplier=Element14; ComponentLink11Description: https://datasheet.ciiva.com/12667/pyu-rc0402-51-rohs-l-6-r-12667067.pdf?src-supplier=Mouser; ComponentLink11URL: https://datasheet.ciiva.com/12667/pyu-rc0402-51-rohs-l-6-r-12667067.pdf?src-supplier=Mouser; ComponentLink12Description: https://datasheet.ciiva.com/7983/1018594-7983486.pdf?src-supplier=Element14; ComponentLink12URL: https://datasheet.ciiva.com/7983/1018594-7983486.pdf?src-supplier=Element14; ComponentLink13Description: http://www.yageo.com/NewPortal/yageodocoutput?fileName=/pdf/R-Chip/PYu-RC_51_RoHS_P_0.pdf&src-supplier=Digi-Key; ComponentLink13URL: http://www.yageo.com/NewPortal/yageodocoutput?fileName=/pdf/R-Chip/PYu-RC_51_RoHS_P_0.pdf&src-supplier=Digi-Key; Features: Moisture Resistant; Height: 0.022inch; Length: 0.063inch; Library Name: Miscellaneous Devices.IntLib; Max Operating Temperature: 155°C; Min Operating Temperature: -55°C; Number of Terminations: 2; Packaging: Tape and Reel; Pricing 1: 1=0.10000, 10=0.04200, 50=0.04200, 100=0.01700, 1000=0.00800, 2500=0.00700, 5000=0.00500, 10000=0.00500 (USD); Resistor Type: Thick Film; RoHS: Yes; RoHS Compliant: Yes; Signal Integrity: Res; Simulation: RESISTOR; Stock 1: 45933; Supplier 1: Digi-Key; Supplier Part Number 1: 311-10KJRCT-ND; Tolerance: 5%; Width: 0.031inch
R15 = Res1: D10/CRCW0402 100 50K 1% ET7 E3; Manufacturer: Vishay Dale; Manufacturer Part Number: CRCW040250K0FKED; Max Power Dissipation: 100mW; Resistance: 10kR; Temperature Coefficient: 150ppm/°C; Tolerance: 5%; Case Code (Imperial): 0603; Case Code (Metric): 1608; Case/Package: 0603; Category: Chip SMD Resistors; Component Kind: Standard; Features: Moisture Resistant; Height: 0.022inch; Length: 0.063inch; Library Name: Miscellaneous Devices.IntLib; Max Operating Temperature: 155°C; Min Operating Temperature: -55°C; Number of Terminations: 2; Packaging: Tape and Reel; Pricing 1: 1=0.10000, 10=0.04200, 50=0.04200, 100=0.01700, 1000=0.00800, 2500=0.00700, 5000=0.00500, 10000=0.00500 (USD); Resistor Type: Thick Film; RoHS: Yes; RoHS Compliant: Yes; Signal Integrity: Res; Simulation: RESISTOR; Stock 1: 45933; Supplier 1: Digikey; Supplier Part Number 1: 541-CRCW040250K0FKEDCT-ND; Width: 0.031inch
S1 = EVQ-P2402W: SWITCH TACTILE SPST-NO 0.02A 15V; MF: {'manufacturer': u'Panasonic Electronic Components'}; Availability: Unavailable; Component Kind: Standard; Library Name: MainSchlib.SchLib; MP: EVQ-P2402W; Package: None; Price: None; Supplier 1: Digi-Key; Supplier Part Number 1: P11081SCT-ND
T1 = PMOS: MOSFET P-CH 30V 4A SOT23-3L; Manufacturer: Alpha & Omega Semiconductor Inc.; Manufacturer Part Number: AO3401A; Component Kind: Standard; ComponentLink1Description: Digi-Key Link; Library Name: MainSchlib.SchLib; RoHS: Yes; Supplier 1: Digi-Key; Supplier Part Number 1: 785-1001-1-ND
T2 = PMOS: Component Kind: Standard; ComponentLink1Description: Digi-Key Link; Library Name: MainSchlib.SchLib; RoHS: Yes; Supplier 1: Digi-Key
U1 = TPS561201DDCR: Buck Switching Regulator IC Positive Adjustable 0.76V 1 Output 1A SOT-23-6 Thin, TSOT-23-6; Manufacturer: Texas Instruments; Category: Voltage Regulators - Switching; Component Kind: Standard; ComponentLink1Description: http://www.ti.com/lit/ds/symlink/tps561201.pdf?src-supplier=Digi-Key; ComponentLink1URL: http://www.ti.com/lit/ds/symlink/tps561201.pdf?src-supplier=Digi-Key; ComponentLink2Description: http://www.ti.com/general/docs/suppproductinfo.tsp?distId=10&amp;gotoUrl=http%3A%2F%2Fwww.ti.com%2Flit%2Fgpn%2Ftps561201&src-supplier=Digi-Key; ComponentLink2URL: http://www.ti.com/general/docs/suppproductinfo.tsp?distId=10&amp;gotoUrl=http%3A%2F%2Fwww.ti.com%2Flit%2Fgpn%2Ftps561201&src-supplier=Digi-Key; Library Name: Schlib.SchLib; Manufacturer Part Number: TPS561201DDCR; Mfr_Name: Texas Instruments; Pricing 1: 1=0.6, 10=0.527, 25=0.4948, 100=0.4037, 250=0.375, 500=0.31916, 1000=0.25533 (USD); RefDes: RefDes; RoHS: Yes; Stock 1: 20361; Supplier 1: Digi-Key; Supplier Part Number 1: 296-47885-1-ND; TYPE: TYPE
U2 = STM32G030F6P6TR: IC MCU 32BIT 32KB FLASH 20TSSOP; Manufacturer: STMicroelectronics; Category: Microcontrollers; Component Kind: Standard; ComponentLink1Description: https://www.st.com/resource/en/datasheet/stm32g030f6.pdf?src-supplier=Digi-Key; ComponentLink1URL: https://www.st.com/resource/en/datasheet/stm32g030f6.pdf?src-supplier=Digi-Key; Library Name: Symbols.SchLib; Manufacturer Part Number: STM32G030F6P6TR; Pricing 1: 1=1.75000, 10=1.57200, 25=1.48320, 100=1.26380, 250=1.18668, 500=1.03834, 1000=0.86034 (USD); RoHS: Yes; RoHS Compliant: Yes; Stock 1: 13536; Supplier 1: Digi-Key; Supplier Part Number 1: 497-STM32G030F6P6TRCT-ND
UP1 = AP3012KTR-G1: IC REG BOOST ADJ 0.5A SOT25; Manufacturer: Diodes; Efficiency: 85%; Max Input Voltage: 16V; Max Output Current: 500mA; Max Output Voltage: 29V; Min Input Voltage: 2.6V; Min Output Voltage: 1.25V; Min Supply Voltage: 1V; Number of Outputs: 1; Operating Supply Current: 100nA; Output Configuration: Positive; Output Type: Adjustable; Switching Frequency: 1.5MHz; Synchronous Rectifier: No; Case/Package: SOT-23-5; Category: Power Supply Modules; Component Kind: Standard; ComponentLink1Description: https://datasheet.ciiva.com/29885/ap3012-29885792.pdf?src-supplier=Avnet; ComponentLink1URL: https://datasheet.ciiva.com/29885/ap3012-29885792.pdf?src-supplier=Avnet; ComponentLink2Description: https://datasheet.ciiva.com/29735/ap3012-29735077.pdf?src-supplier=Digi-Key; ComponentLink2URL: https://datasheet.ciiva.com/29735/ap3012-29735077.pdf?src-supplier=Digi-Key; ComponentLink3Description: https://datasheet.ciiva.com/29735/ap3012-29735068.pdf?src-supplier=Digi-Key; ComponentLink3URL: https://datasheet.ciiva.com/29735/ap3012-29735068.pdf?src-supplier=Digi-Key; Lead Free: Lead Free; Library Name: MainSchlib.SchLib; Manufacturer Part Number: AP3012KTR-G1; Max Operating Temperature: 85°C; Min Operating Temperature: -40°C; Mount: Surface Mount; Number of Pins: 5; Operating Supply Voltage: 16V; Output Current: 500mA; Output Voltage: 29V; Package Quantity: 3000; Packaging: Tape and Reel; Pricing 1: 1=0.47000, 10=0.38000, 25=0.34760, 100=0.23430, 250=0.19392, 500=0.17776, 1000=0.13332 (USD); RoHS: Yes; Stock 1: 74092; Supplier 1: Digi-Key; Supplier Part Number 1: AP3012KTR-G1DICT-ND; Topology: Boost; Weight: 0.001058oz
